# Altium schematic sheet Peripherals.SchDoc("Microcontroler Peripherals")
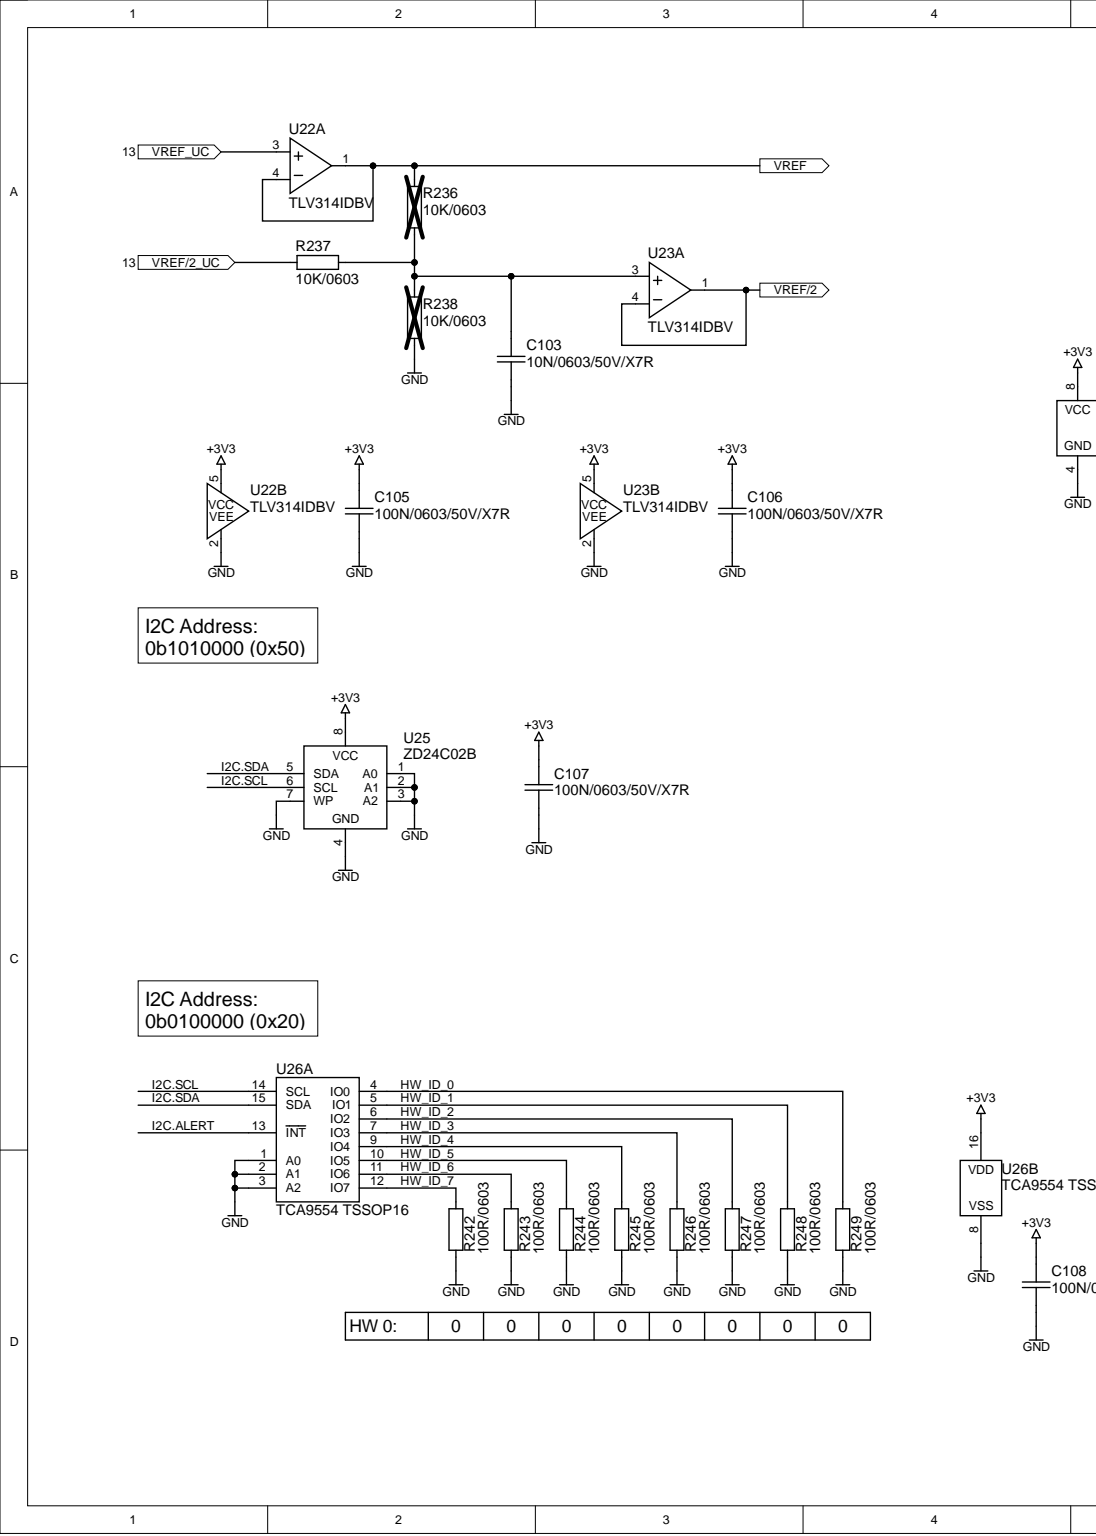
[diagram p0: Peripherals.SchDoc, left]
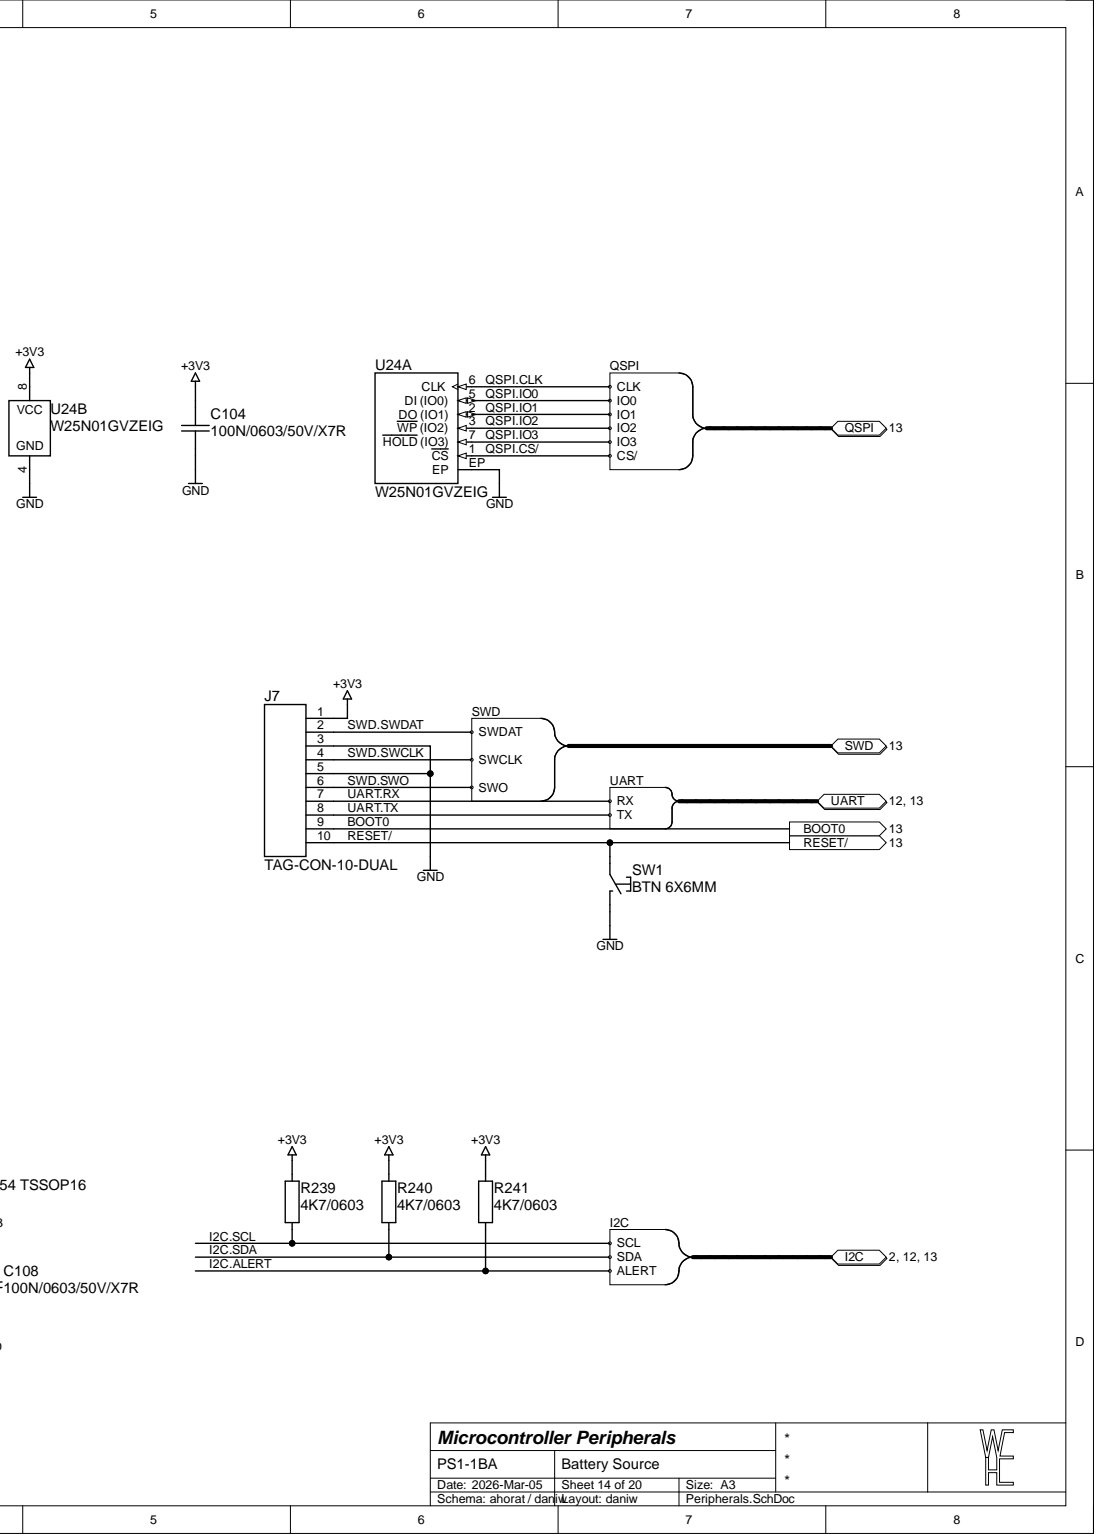
[diagram p1: Peripherals.SchDoc, right]

## Parts
C103  10N/0603/50V/X7R  [CAP0603_X7R]
C104  100N/0603/50V/X7R  [CAP0603_X7R]
C105  100N/0603/50V/X7R  [CAP0603_X7R]
C106  100N/0603/50V/X7R  [CAP0603_X7R]
C107  100N/0603/50V/X7R  [CAP0603_X7R]
C108  100N/0603/50V/X7R  [CAP0603_X7R]
J7  TAG-CON-10-DUAL  [TC2050-2]
R236  10K/0603  [R0603-0.40-WW]
R237  10K/0603  [R0603-0.40-WW]
R238  10K/0603  [R0603-0.40-WW]
R239  4K7/0603  [R0603-0.40-WW]
R240  4K7/0603  [R0603-0.40-WW]
R241  4K7/0603  [R0603-0.40-WW]
R242  100R/0603  [R0603-0.40-WW]
R243  100R/0603  [R0603-0.40-WW]
R244  100R/0603  [R0603-0.40-WW]
R245  100R/0603  [R0603-0.40-WW]
R246  100R/0603  [R0603-0.40-WW]
R247  100R/0603  [R0603-0.40-WW]
R248  100R/0603  [R0603-0.40-WW]
R249  100R/0603  [R0603-0.40-WW]
SW1  BTN 6X6MM  [BTN-6X6MM-4.3MM-YELLOW]
U22  TLV314IDBV  [SOT2395P280X125-5-NW]  (parts A,B)
U23  TLV314IDBV  [SOT2395P280X125-5-NW]  (parts A,B)
U24  W25N01GVZEIG  [SON127P800X600X080-8-EP340X430]  (parts A,B)
U25  ZD24C02B  [SOP127P600X155-8-SW]
U26  TCA9554 TSSOP16  [SOP065P640X110-16NW]  (parts A,B)

## Ports
BOOT0, I2C, I2C.ALERT, I2C.SCL, I2C.SDA, QSPI, QSPI.CLK, QSPI.CS/, QSPI.IO0, QSPI.IO1, QSPI.IO2, QSPI.IO3, RESET/, SWD, SWD.SWCLK, SWD.SWDAT, SWD.SWO, UART, UART.RX, UART.TX, VREF, VREF/2, VREF/2_UC, VREF_UC

## Nets
+3V3: C104.1 C105.1 C106.1 C107.1 C108.1 J7.1 R239.1 R240.1 R241.1 U22.5 U23.5 U24.8 U25.8 U26.16
GND: C103.2 C104.2 C105.2 C106.2 C107.2 C108.2 J7.3 J7.5 R238.2 R242.2 R243.2 R244.2 R245.2 R246.2 R247.2 R248.2 R249.2 SW1.2 U22.2 U23.2 U24.4 U24.EP U25.1(A0) U25.2(A1) U25.3(A2) U25.4 U25.7(WP) U26.1(A0) U26.2(A1) U26.3(A2) U26.8
BOOT0: J7.9 ; port BOOT0
HW_ID_0: R249.1 U26.4(IO0)
HW_ID_1: R248.1 U26.5(IO1)
HW_ID_2: R247.1 U26.6(IO2)
HW_ID_3: R246.1 U26.7(IO3)
HW_ID_4: R245.1 U26.9(IO4)
HW_ID_5: R244.1 U26.10(IO5)
HW_ID_6: R243.1 U26.11(IO6)
HW_ID_7: R242.1 U26.12(IO7)
I2C.ALERT: R241.2 U26.13(INT) ; port I2C
I2C.SCL: R239.2 U25.6(SCL) U26.14(SCL) ; port I2C
I2C.SDA: R240.2 U25.5(SDA) U26.15(SDA) ; port I2C
QSPI.CLK: U24.6(CLK) ; port QSPI
QSPI.CS/: U24.1(CS) ; port QSPI
QSPI.IO0: U24.5(DI_(IO0)) ; port QSPI
QSPI.IO1: U24.2(DO_(IO1)) ; port QSPI
QSPI.IO2: U24.3(WP_(IO2)) ; port QSPI
QSPI.IO3: U24.7(HOLD_(IO3)) ; port QSPI
RESET/: J7.10 SW1.1 ; port RESET/
SWD.SWCLK: J7.4 ; port SWD
SWD.SWDAT: J7.2 ; port SWD
SWD.SWO: J7.6 ; port SWD
UART.RX: J7.7 ; port UART
UART.TX: J7.8 ; port UART
VREF: R236.1 U22.1 U22.4 ; port VREF
VREF/2: U23.1 U23.4 ; port VREF/2
VREF/2_UC: R237.1 ; port VREF/2_UC
VREF_UC: U22.3 ; port VREF_UC
NetC103_1: C103.1 R236.2 R237.2 R238.1 U23.3

## Library data of the parts
C103 = 10N/0603/50V/X7R: CAP SM 0603 10nF 50V X7R; Manufacturer: GENERIC; Manufacturer 1: YAGEO; Manufacturer Part Number 1: CC0603KPX7R9BB103; Absolute Value: 1E-8; Database Table Name: C$; Eurocircuits GPN: GPC0603103; Component Kind: Standard; HelpURL: https://www.yageo.com/upload/media/product/app/datasheet/mlcc/upy-gphc_x7r_6_3v-to-250v.pdf; Manufacturer 2: YAGEO; Manufacturer Part Number 2: CC0603KRX7R9BB103; Manufacturer Part Number 3: CC0603KRX7R9BB103; Package: 0603; Part Number: 10N/0603/50V/X7R; Supplier 1: Digi-Key; Supplier 2: Digi-Key; Supplier 3: LCSC; Supplier Part Number 1: 311-1572-1-ND; Supplier Part Number 2: 311-1085-1-ND; Supplier Part Number 3: C100042; Value: 10nF; Library Name: daniw_altium_lib.DbLib
C104-C108 = 100N/0603/50V/X7R: CAP SM 0603 100nF 50V X7R; Manufacturer: GENERIC; Manufacturer 1: YAGEO; Manufacturer Part Number 1: CC0603KPX7R9BB104; Absolute Value: 1E-7; Database Table Name: C$; Eurocircuits GPN: GPC0603104; Component Kind: Standard; HelpURL: https://www.yageo.com/upload/media/product/app/datasheet/mlcc/upy-gphc_x7r_6_3v-to-250v.pdf; JLC Type: Basic; Manufacturer 2: YAGEO; Manufacturer Part Number 2: CC0603MRX7R9BB104; Manufacturer Part Number 3: CC0603KRX7R9BB104; Package: 0603; Part Number: 100N/0603/50V/X7R; Supplier 1: Digi-Key; Supplier 2: Digi-Key; Supplier 3: LCSC; Supplier Part Number 1: 311-3567-1-ND; Supplier Part Number 2: 311-4110-1-ND; Supplier Part Number 3: C14663; Value: 100nF; Library Name: daniw_altium_lib.DbLib
J7 = TAG-CON-10-DUAL: Tag-Connect 2050, 10 pins, two-sided; Database Table Name: Misc$; Component Kind: Standard; HelpURL: https://www.tag-connect.com/wp-content/uploads/bsk-pdf-manager/TC2050-IDC_Datasheet_7.pdf; Manufacturer: TAG-CONNECT; Part Number: TAG-CON-10-DUAL; Library Name: daniw_altium_lib.DbLib
R236-R238 = 10K/0603: RES SM 0603 10K; Manufacturer: GENERIC; Manufacturer 1: YAGEO; Manufacturer Part Number 1: RC0603FR-1310KL; Absolute Value: 10000; Database Table Name: R$; Eurocircuits GPN: GPR060310K; Component Kind: Standard; HelpURL: https://www.yageogroup.com/content/datasheet/asset/file/PYU-RC_GROUP_51_ROHS_L; JLC Type: Basic; Manufacturer 2: YAGEO; Manufacturer 3: UNI-ROYAL(Uniroyal Elec); Manufacturer Part Number 2: RC0603FR-1010KL; Manufacturer Part Number 3: 0603WAF1002T5E; Package: 0603; Part Number: 10K/0603; Supplier 1: Digi-Key; Supplier 2: Digi-Key; Supplier 3: LCSC; Supplier Part Number 1: 13-RC0603FR-1310KLCT-ND; Supplier Part Number 2: 13-RC0603FR-1010KLCT-ND; Supplier Part Number 3: C25804; Value: 10K; Library Name: daniw_altium_lib.DbLib
R239-R241 = 4K7/0603: RES SM 0603 4K7; Manufacturer: GENERIC; Manufacturer 1: YAGEO; Manufacturer Part Number 1: RC0603FR-134K7L; Absolute Value: 4700; Database Table Name: R$; Eurocircuits GPN: GPR06034K7; Component Kind: Standard; HelpURL: https://www.yageogroup.com/content/datasheet/asset/file/PYU-RC_GROUP_51_ROHS_L; JLC Type: Basic; Manufacturer 2: YAGEO; Manufacturer 3: UNI-ROYAL(Uniroyal Elec); Manufacturer Part Number 2: RC0603FR-104K7L; Manufacturer Part Number 3: 0603WAF4701T5E; Package: 0603; Part Number: 4K7/0603; Supplier 1: Digi-Key; Supplier 2: Digi-Key; Supplier 3: LCSC; Supplier Part Number 1: 13-RC0603FR-134K7LCT-ND; Supplier Part Number 2: 13-RC0603FR-104K7LCT-ND; Supplier Part Number 3: C23162; Value: 4K7; Library Name: daniw_altium_lib.DbLib
R242-R249 = 100R/0603: RES SM 0603 100R; Manufacturer: GENERIC; Manufacturer 1: YAGEO; Manufacturer Part Number 1: RC0603FR-13100RL; Absolute Value: 100; Database Table Name: R$; Eurocircuits GPN: GPR0603100R; Component Kind: Standard; HelpURL: https://www.yageogroup.com/content/datasheet/asset/file/PYU-RC_GROUP_51_ROHS_L; Manufacturer 2: YAGEO; Manufacturer 3: UNI-ROYAL(Uniroyal Elec); Manufacturer Part Number 2: RC0603FR-10100RL; Manufacturer Part Number 3: 0603WAF1000T5E; Package: 0603; Part Number: 100R/0603; Supplier 1: Digi-Key; Supplier 2: Digi-Key; Supplier 3: LCSC; Supplier Part Number 1: 13-RC0603FR-13100RLCT-ND; Supplier Part Number 2: 13-RC0603FR-10100RLCT-ND; Supplier Part Number 3: C22775; Value: 100R; Library Name: daniw_altium_lib.DbLib
SW1 = BTN 6X6MM 4.3MM 1.5N: Pushbutton switch, PCB mount, 6x6mm, 4.3mm height, 1.5N operating force; Manufacturer 1: Omron Electronics Inc-EMC Div; Manufacturer Part Number 1: B3F-1002; Database Table Name: Misc$; Component Kind: Standard; HelpURL: https://omronfs.omron.com/en_US/ecb/products/pdf/en-b3f.pdf; Part Number: BTN 6X6MM 4.3MM 1.5N; Supplier 1: Digi-Key; Supplier Part Number 1: SW401-ND; Library Name: daniw_altium_lib.DbLib
U22 U23 = TLV314 SOT23: TLV314, 3-MHz, Low-Power, Internal EMI Filter, RRIO, Operational Amplifier; Manufacturer: Texas Instruments; Manufacturer Part Number 1: TLV314IDBVR; Database Table Name: Integrated$; Component Kind: Standard; HelpURL: https://www.ti.com/lit/ds/symlink/tlv4314.pdf; Manufacturer 1: Texas Instruments; Manufacturer 2: Texas Instruments; Manufacturer 3: Texas Instruments; Manufacturer Part Number 2: TLV314IDBVT; Manufacturer Part Number 3: TLV314IDBVR; Package: SOT23-5; Part Number: TLV314 SOT23; Supplier 1: Digi-Key; Supplier 2: Digi-Key; Supplier 3: LCSC; Supplier Part Number 1: 296-46275-1-ND; Supplier Part Number 2: 296-44110-2-ND; Supplier Part Number 3: C133032; Library Name: daniw_altium_lib.DbLib
U24 = W25N01GVZEIG: W25N01GVZEIG 3V 1G-BIT Serial SLC NAND Flash Memoryw with Dual/Quad SPI Buffer Read & Continuous Read; Manufacturer: Winbond; Manufacturer 1: Winbond Electronics; Manufacturer Part Number 1: W25N01GVZEIG TR; Database Table Name: Integrated$; Component Kind: Standard; HelpURL: https://www.winbond.com/resource-files/W25N01GV%20Rev%20O%20092619.pdf; JLC Type: Extended; Manufacturer 2: Winbond Electronics; Manufacturer 3: Winbond; Manufacturer Part Number 2: W25N01GVZEIG; Manufacturer Part Number 3: W25N01GVZEIG; Package: DFN-8; Part Number: W25N01GVZEIG; Supplier 1: Digi-Key; Supplier 2: Digi-Key; Supplier 3: LCSC; Supplier Part Number 1: 256-W25N01GVZEIGTRCT-ND; Supplier Part Number 2: 256-W25N01GVZEIG-ND; Supplier Part Number 3: C907680; Library Name: daniw_altium_lib.DbLib
U25 = ZD24C02B: I2C-Compatible (2-wire) Serial EEPROM 2K bits; Manufacturer: Zetta; Database Table Name: Integrated$; Component Kind: Standard; HelpURL: https://datasheet.lcsc.com/lcsc/2402020955_Zetta-ZD24C02B-SSGMB_C5374786.pdf; JLC Type: Extended; Manufacturer 3: Zetta; Manufacturer Part Number 3: ZD24C02B-SSGMB; Package: SOIC-8; Part Number: ZD24C02B; Supplier 3: LCSC; Supplier Part Number 3: C5374786; Library Name: daniw_altium_lib.DbLib
U26 = TCA9554 TSSOP16: TCA9554 Low Voltage 8-Bit I2C and SMBus Low-Power I/O Expander With Interrupt Output and Configuration Registers; Manufacturer: Texas Instruments; Manufacturer Part Number 1: TCA9554PWR; Database Table Name: Integrated$; Component Kind: Standard; HelpURL: https://www.ti.com/lit/ds/symlink/tca9554.pdf; Manufacturer 1: Texas Instruments; Manufacturer 3: TI; Manufacturer Part Number 3: TCA9554PWR; Package: TSSOP-16; Part Number: TCA9554 TSSOP16; Supplier 1: Digi-Key; Supplier 3: LCSC; Supplier Part Number 1: 296-30135-1-ND; Supplier Part Number 3: C477924; Library Name: daniw_altium_lib.DbLib
